# Altium schematic sheet peripheral device.SchDoc("peripheral device")
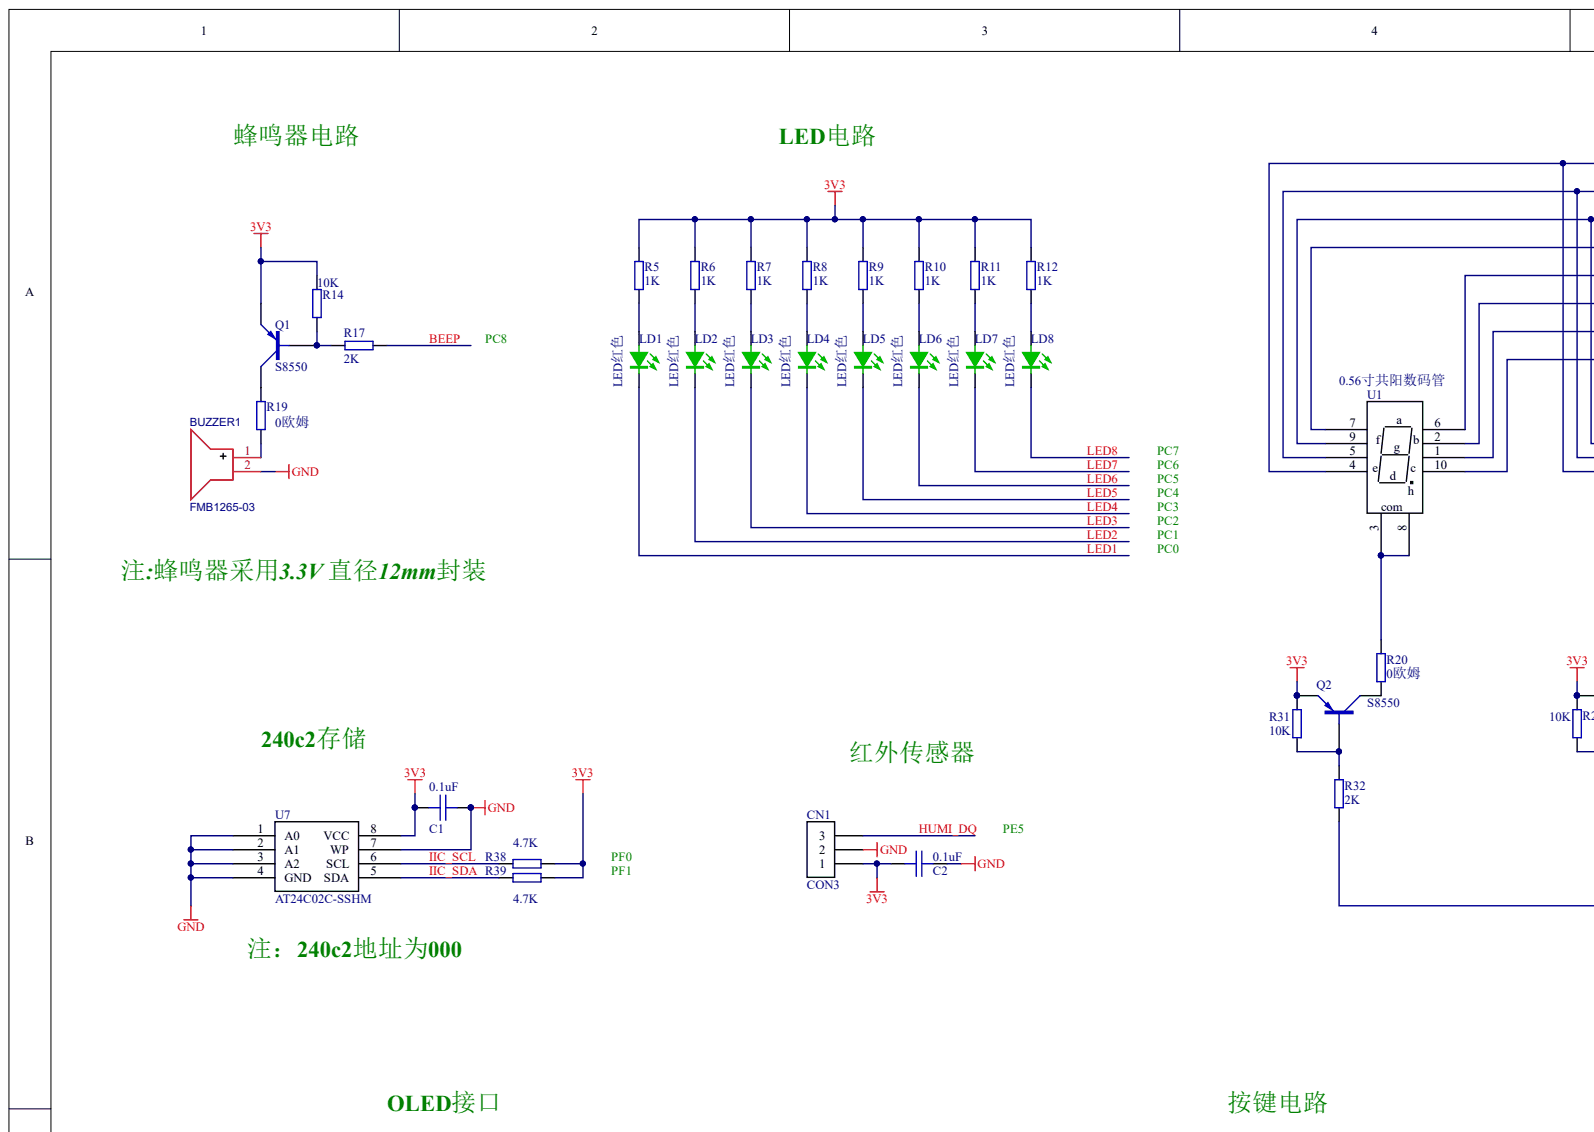
[diagram p0: peripheral device.SchDoc, top left]
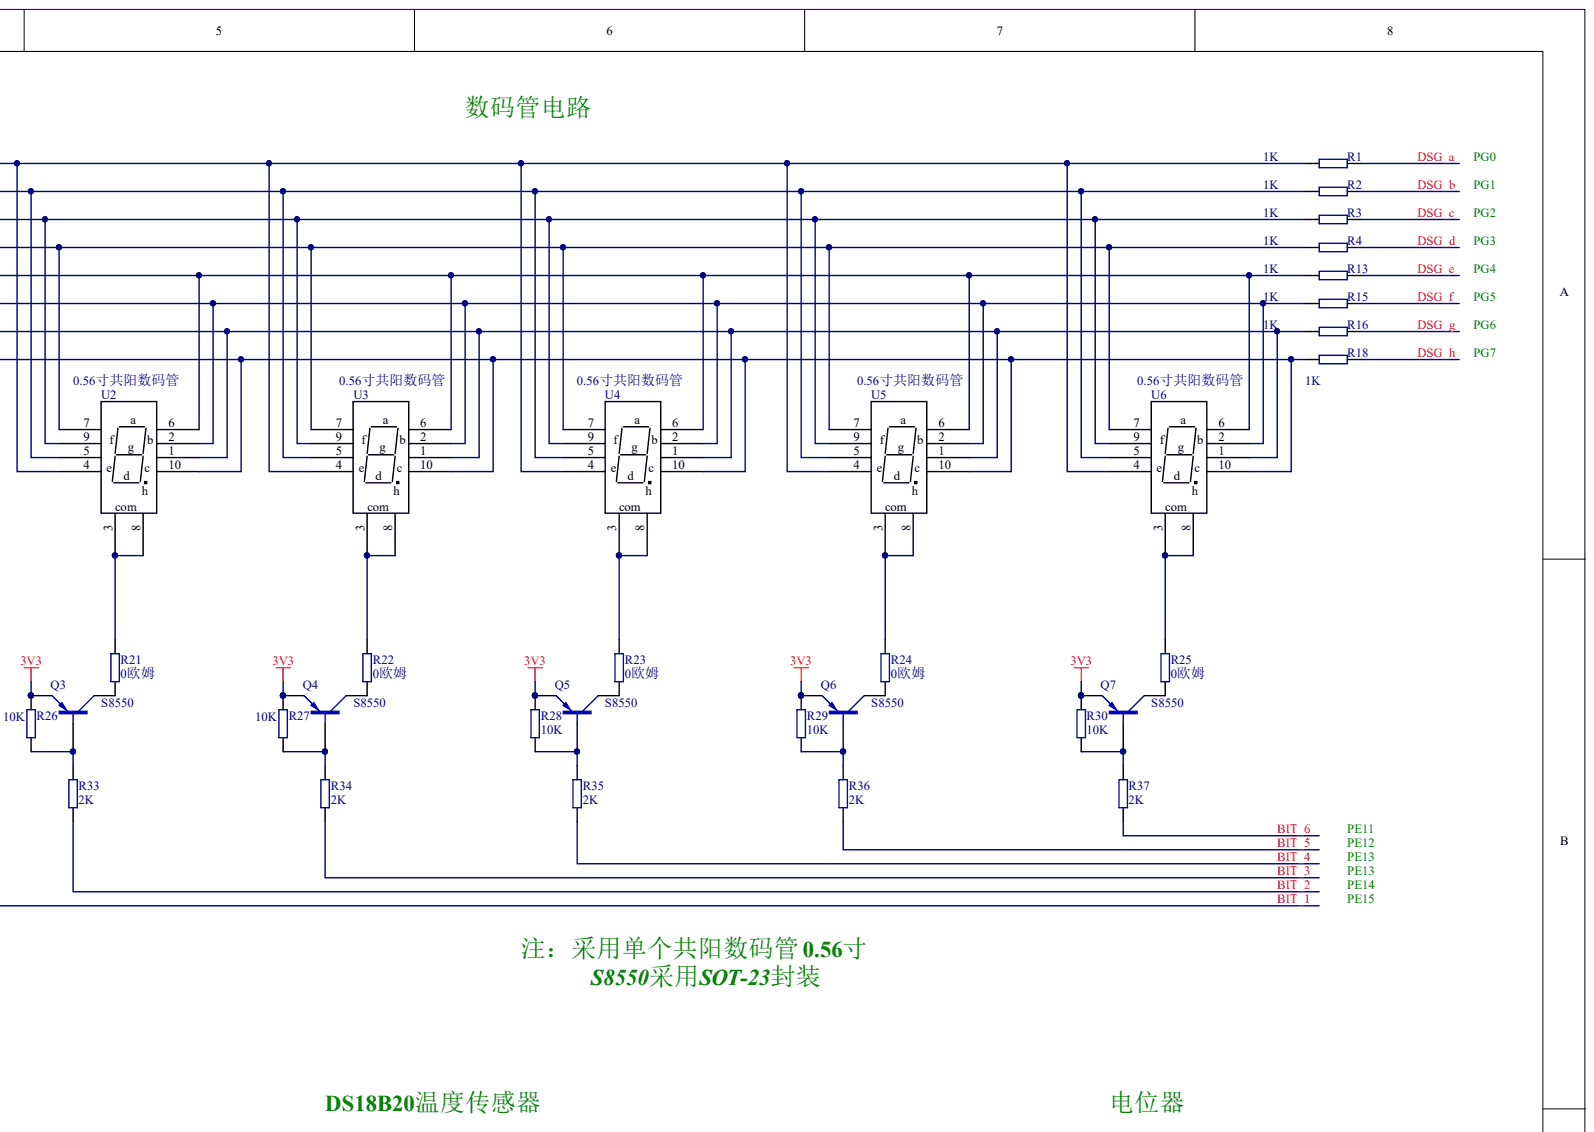
[diagram p1: peripheral device.SchDoc, top right]
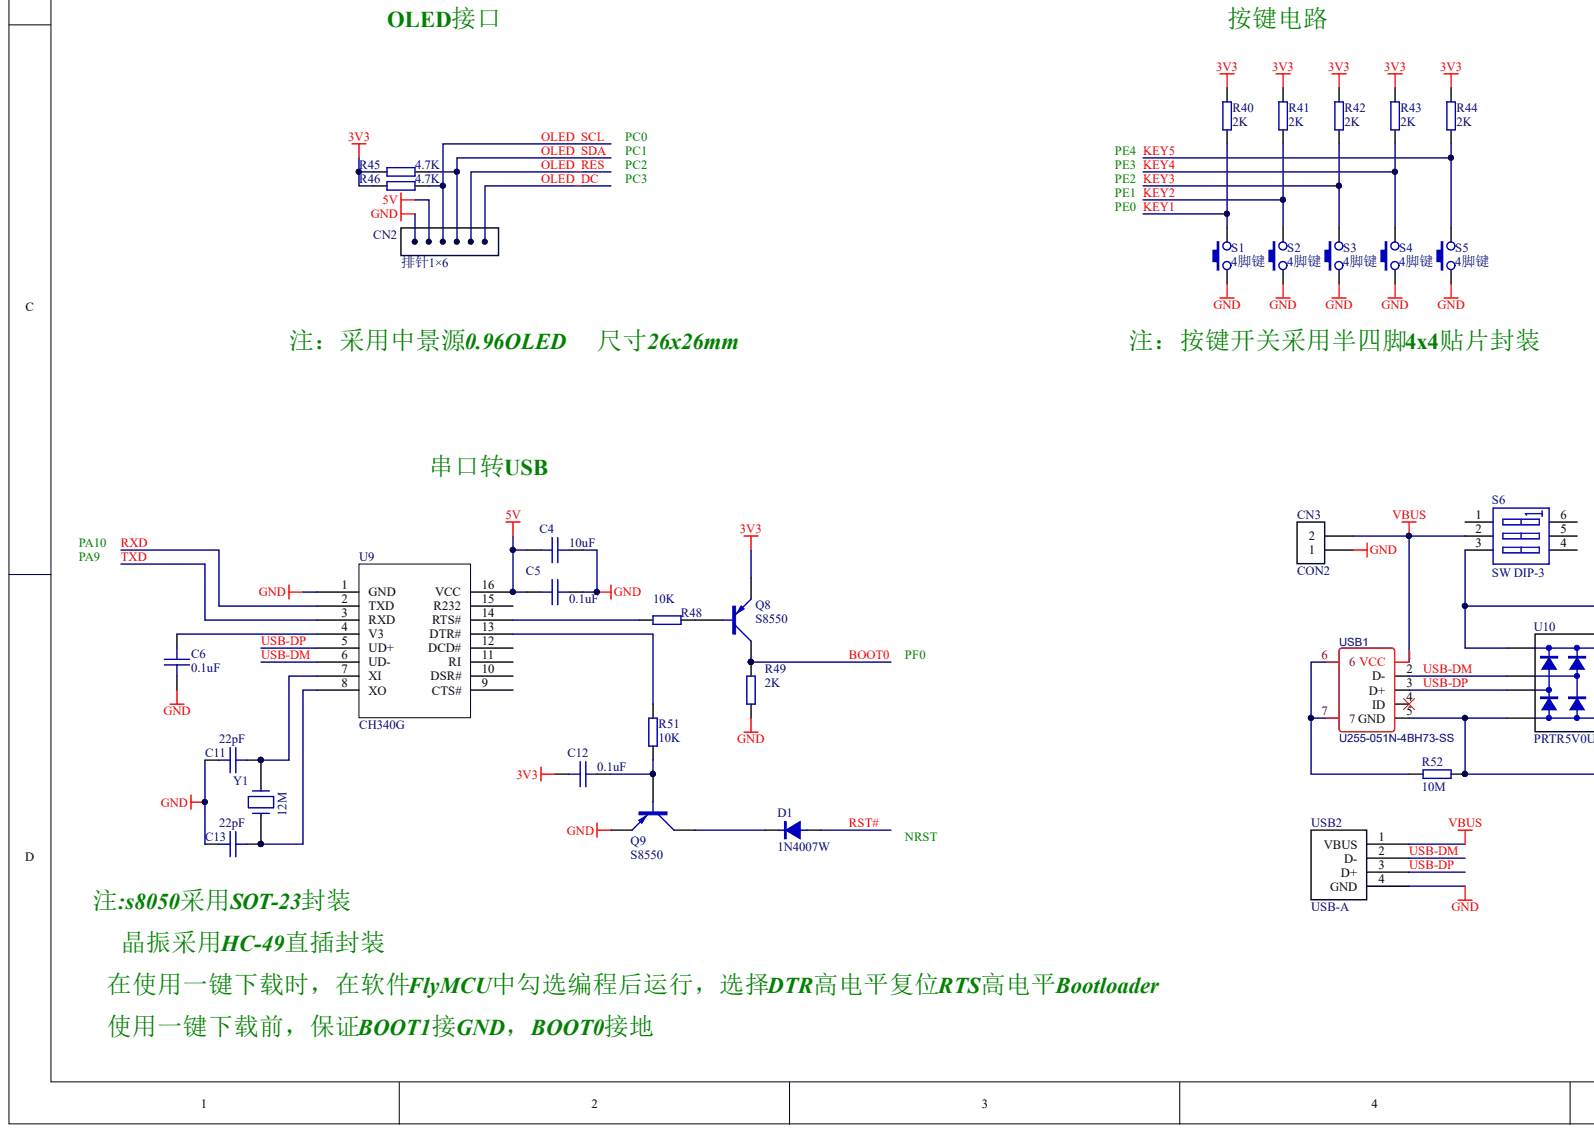
[diagram p2: peripheral device.SchDoc, bottom left]
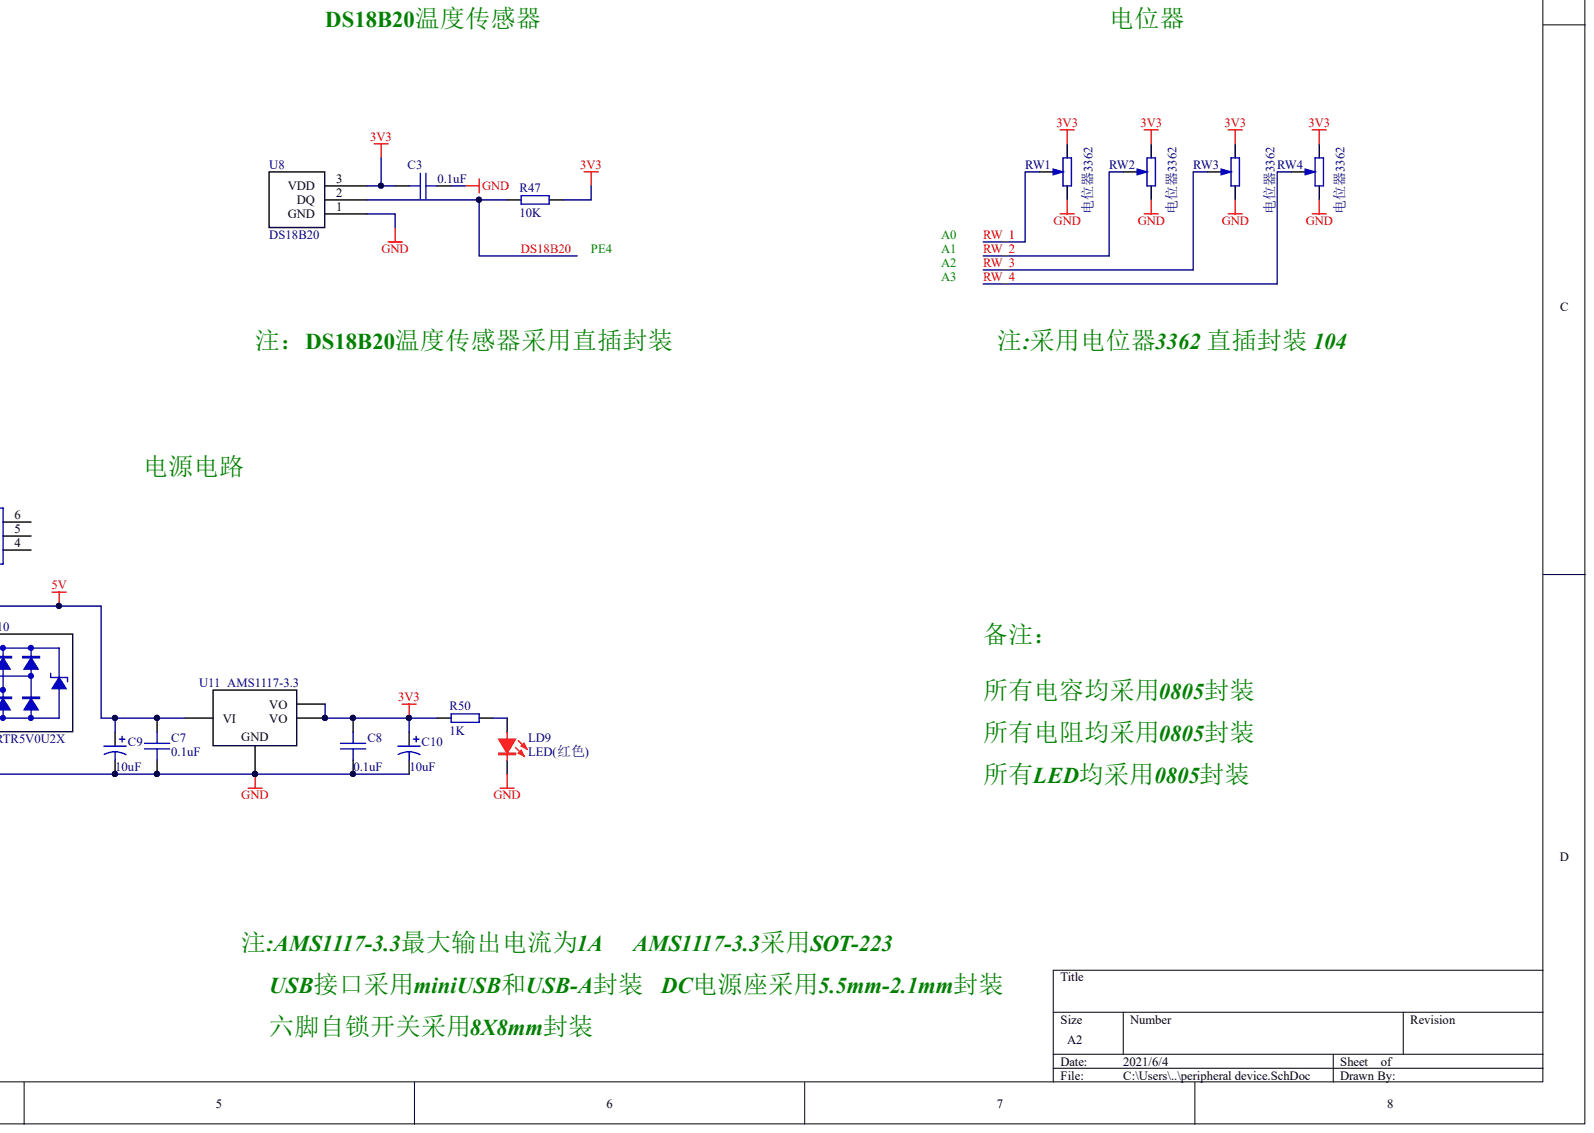
[diagram p3: peripheral device.SchDoc, bottom right]

## Parts
BUZZER1  FMB1265-03  [BUZ-TH_BD12.0-P7.60-D0.6-FD]
C1  0.1uF  [0805C]
C2  0.1uF  [0805C]
C3  0.1uF  [0805C]
C4  10uF  [0805C]
C5  0.1uF  [0805C]
C6  0.1uF  [0805C]
C7  0.1uF  [0805C]
C8  0.1uF  [0805C]
C9  10uF  [3528]
C10  10uF  [3528]
C11  22pF  [0805C]
C12  0.1uF  [0805C]
C13  22pF  [0805C]
CN1  CON3  [SIP-3(2.54)]
CN2  排针1×6  [OLED-0.96]
CN3  CON2  [CONN-TH_2P-P3.81_KF124-3.81-2P]
D1  1N4007W  [SOD-123]
LD1  LED红色  [0805D]
LD2  LED红色  [0805D]
LD3  LED红色  [0805D]
LD4  LED红色  [0805D]
LD5  LED红色  [0805D]
LD6  LED红色  [0805D]
LD7  LED红色  [0805D]
LD8  LED红色  [0805D]
LD9  LED(红色)  [0805D]
Q1  S8550  [SOT-23(2.4)]
Q2  S8550  [SOT-23(2.4)]
Q3  S8550  [SOT-23(2.4)]
Q4  S8550  [SOT-23(2.4)]
Q5  S8550  [SOT-23(2.4)]
Q6  S8550  [SOT-23(2.4)]
Q7  S8550  [SOT-23(2.4)]
Q8  S8550  [SOT-23(2.4)]
Q9  S8550  [SOT-23(2.4)]
R1  1K  [0805R]
R2  1K  [0805R]
R3  1K  [0805R]
R4  1K  [0805R]
R5  1K  [0805R]
R6  1K  [0805R]
R7  1K  [0805R]
R8  1K  [0805R]
R9  1K  [0805R]
R10  1K  [0805R]
R11  1K  [0805R]
R12  1K  [0805R]
R13  1K  [0805R]
R14  10K  [0805R]
R15  1K  [0805R]
R16  1K  [0805R]
R17  2K  [0805R]
R18  1K  [0805R]
R19  0欧姆  [0805R]
R20  0欧姆  [0805R]
R21  0欧姆  [0805R]
R22  0欧姆  [0805R]
R23  0欧姆  [0805R]
R24  0欧姆  [0805R]
R25  0欧姆  [0805R]
R26  10K  [0805R]
R27  10K  [0805R]
R28  10K  [0805R]
R29  10K  [0805R]
R30  10K  [0805R]
R31  10K  [0805R]
R32  2K  [0805R]
R33  2K  [0805R]
R34  2K  [0805R]
R35  2K  [0805R]
R36  2K  [0805R]
R37  2K  [0805R]
R38  4.7K  [0805R]
R39  4.7K  [0805R]
R40  2K  [0805R]
R41  2K  [0805R]
R42  2K  [0805R]
R43  2K  [0805R]
R44  2K  [0805R]
R45  4.7K  [0805R]
R46  4.7K  [0805R]
R47  10K  [0805R]
R48  10K  [0805R]
R49  2K  [0805R]
R50  1K  [0805R]
R51  10K  [0805R]
R52  10M  [0805R]
RW1  电位器3362  [电位器3362]
RW2  电位器3362  [电位器3362]
RW3  电位器3362  [电位器3362]
RW4  电位器3362  [电位器3362]
S1  4脚键  [KEY4x4]
S2  4脚键  [KEY4x4]
S3  4脚键  [KEY4x4]
S4  4脚键  [KEY4x4]
S5  4脚键  [KEY4x4]
S6  SW DIP-3  [六脚按键开关]
U1  0.56寸共阳数码管  [single]
U2  0.56寸共阳数码管  [single]
U3  0.56寸共阳数码管  [single]
U4  0.56寸共阳数码管  [single]
U5  0.56寸共阳数码管  [single]
U6  0.56寸共阳数码管  [single]
U7  AT24C02C-SSHM  [SOP-8(1.27,6.0)]
U8  DS18B20  [TO-92]
U9  CH340G  [SOP-16(1.27,6.0)]
U10  PRTR5V0U2X  [SOT-143]
U11  AMS1117-3.3  [SOT-223]
USB1  U255-051N-4BH73-SS  [MICRO-USB-TH_U255-051N-4BH73]
USB2  USB-A  [USB-A-TH_USB-302-T]
Y1  12M  [XTAL(HC-49)]

## Nets
3V3: C1.2 C2.1 C3.1 C8.2 C10.1 C12.1 CN1.1 Q1.2 Q2.2 Q3.2 Q4.2 Q5.2 Q6.2 Q7.2 Q8.2 R5.2 R6.2 R7.2 R8.2 R9.2 R10.2 R11.2 R12.2 R14.2 R26.2 R27.2 R28.2 R29.2 R30.2 R31.2 R38.2 R39.2 R40.2 R41.2 R42.2 R43.2 R44.2 R45.2 R46.2 R47.1 R50.1 RW1.1 RW2.1 RW3.1 RW4.1 U7.8(VCC) U8.3 U11.2(VO) U11.4(VO)
5V: C4.1 C5.1 C7.2 C9.1 CN2.2 S6.3 U9.16(VCC) U10.4 U11.3(VI)
GND: BUZZER1.2 C1.1 C2.2 C3.2 C4.2 C5.2 C6.1 C7.1 C8.1 C9.2 C10.2 C11.1 C13.1 CN1.2 CN2.1 CN3.1 LD9.2 Q9.2 R49.2 R52.2 RW1.3 RW2.3 RW3.3 RW4.3 S1.1 S2.1 S3.1 S4.1 S5.1 U7.1(A0) U7.2(A1) U7.3(A2) U7.4(GND) U7.7(WP) U8.1 U9.1(GND) U10.1 U11.1 USB1.5(GND) USB2.4(GND)
VBUS: CN3.2 S6.2 USB1.1(VCC) USB2.1(VBUS)
BEEP: R17.2
BIT_1: R32.2
BIT_2: R33.2
BIT_3: R34.2
BIT_4: R35.2
BIT_5: R36.2
BIT_6: R37.2
BOOT0: Q8.3 R49.1
DS18B20: R47.2 U8.2
DSG_A: R1.1
DSG_B: R2.1
DSG_C: R3.1
DSG_D: R4.1
DSG_E: R13.1
DSG_F: R15.1
DSG_G: R16.1
DSG_H: R18.1
HUMI_DQ: CN1.3
IIC_SCL: R38.1 U7.6(SCL)
IIC_SDA: R39.1 U7.5(SDA)
KEY1: R40.1 S1.2
KEY2: R41.1 S2.2
KEY3: R42.1 S3.2
KEY4: R43.1 S4.2
KEY5: R44.1 S5.2
LED1: LD1.2
LED2: LD2.2
LED3: LD3.2
LED4: LD4.2
LED5: LD5.2
LED6: LD6.2
LED7: LD7.2
LED8: LD8.2
OLED_DC: CN2.6
OLED_RES: CN2.5
OLED_SCL: CN2.3 R46.1
OLED_SDA: CN2.4 R45.1
RST#: D1.1
RW_1: RW1.2
RW_2: RW2.2
RW_3: RW3.2
RW_4: RW4.2
RXD: U9.2(TXD)
TXD: U9.3(RXD)
USB-DM: U9.6(UD-) U10.3 USB1.2(D-) USB2.2(D-)
USB-DP: U9.5(UD+) U10.2 USB1.3(D+) USB2.3(D+)
NetBUZZER1_1: BUZZER1.1 R19.2
NetC6_2: C6.2 U9.4(V3)
NetC11_2: C11.2 U9.7(XI) Y1.1
NetC12_2: C12.2 Q9.1 R51.2
NetC13_2: C13.2 U9.8(XO) Y1.2
NetD1_2: D1.2 Q9.3
NetLD1_1: LD1.1 R5.1
NetLD2_1: LD2.1 R6.1
NetLD3_1: LD3.1 R7.1
NetLD4_1: LD4.1 R8.1
NetLD5_1: LD5.1 R9.1
NetLD6_1: LD6.1 R10.1
NetLD7_1: LD7.1 R11.1
NetLD8_1: LD8.1 R12.1
NetLD9_1: LD9.1 R50.2
NetQ1_1: Q1.1 R14.1 R17.1
NetQ1_3: Q1.3 R19.1
NetQ2_1: Q2.1 R31.1 R32.1
NetQ2_3: Q2.3 R20.1
NetQ3_1: Q3.1 R26.1 R33.1
NetQ3_3: Q3.3 R21.1
NetQ4_1: Q4.1 R27.1 R34.1
NetQ4_3: Q4.3 R22.1
NetQ5_1: Q5.1 R28.1 R35.1
NetQ5_3: Q5.3 R23.1
NetQ6_1: Q6.1 R29.1 R36.1
NetQ6_3: Q6.3 R24.1
NetQ7_1: Q7.1 R30.1 R37.1
NetQ7_3: Q7.3 R25.1
NetQ8_1: Q8.1 R48.1
NetR1_2: R1.2 U1.4(e) U2.4(e) U3.4(e) U4.4(e) U5.4(e) U6.4(e)
NetR2_2: R2.2 U1.5 U2.5 U3.5 U4.5 U5.5 U6.5
NetR3_2: R3.2 U1.9(f) U2.9(f) U3.9(f) U4.9(f) U5.9(f) U6.9(f)
NetR4_2: R4.2 U1.7 U2.7 U3.7 U4.7 U5.7 U6.7
NetR13_2: R13.2 U1.6 U2.6 U3.6 U4.6 U5.6 U6.6
NetR15_2: R15.2 U1.2(b) U2.2(b) U3.2(b) U4.2(b) U5.2(b) U6.2(b)
NetR16_2: R16.2 U1.1 U2.1 U3.1 U4.1 U5.1 U6.1
NetR18_2: R18.2 U1.10(c) U2.10(c) U3.10(c) U4.10(c) U5.10(c) U6.10(c)
NetR20_2: R20.2 U1.3 U1.8(h)
NetR21_2: R21.2 U2.3 U2.8(h)
NetR22_2: R22.2 U3.3 U3.8(h)
NetR23_2: R23.2 U4.3 U4.8(h)
NetR24_2: R24.2 U5.3 U5.8(h)
NetR25_2: R25.2 U6.3 U6.8(h)
NetR48_2: R48.2 U9.14(RTS#)
NetR51_1: R51.1 U9.13(DTR#)
NetR52_1: R52.1 USB1.6 USB1.7

Not connected: S6 1 4 5 6; U9 9(CTS#) 10(DSR#) 11(RI) 12(DCD#) 15(R232); USB1 4(ID)

## Library data of the parts
BUZZER1 = FMB1265-03: BOM_Manufacturer: FUET; Contributor: LCSC; BOM_JLCPCB Part Class: Extended Part; BOM_Manufacturer Part: FMB1265-03; BOM_Supplier: LCSC; BOM_Supplier Part: C482354; Component Kind: Standard; Library Name: BUZZ-3v3.SCHLIB; spicePre: B; spiceSymbolName: FMB1265-03
C1-C8 C11-C13 = 0805电容: Component Kind: Standard; Library Name: 电容Capacitor.SchLib
C9 C10 = Cap Pol1: Polarized Capacitor (Radial); Component Kind: Standard; LatestRevisionDate: 17-Jul-2002; LatestRevisionNote: Re-released for DXP Platform.; Library Name: Miscellaneous Devices.IntLib; PackageDescription: Polarized Capacitor (Radial); 2 Leads; PackageReference: RB7.6-15; Published: 8-Jun-2000; Signal Integrity: Cap Pol; Simulation: CAP; Value: 10uF; Publisher: Altium Limited
D1 = 1N4007W: 1A整流二极管; Component Kind: Standard; Library Name: 二极管Diode.SchLib
LD1-LD8 = LED(翠绿): Component Kind: Standard; Library Name: 二极管Diode.SchLib
Q1-Q9 = S8550: PNP晶体管，500mA，25V; Component Kind: Standard; Library Name: 晶体管Transistor.SchLib
R1-R52 = 0805电阻: Component Kind: Standard; Library Name: 电阻Resistor.SchLib
RW1-RW4 = 电位器3296W: Component Kind: Standard; Library Name: 电阻Resistor.SchLib
S1-S5 = 2脚键: Component Kind: Standard; Library Name: 按键开关Switch.SchLib
S6 = SW DIP-3: DIP Switch, 3 Position, SPST; Code_JEDEC: MO-001-AM; Component Kind: Standard; LatestRevisionDate: 17-Jul-2002; LatestRevisionNote: Re-released for DXP Platform.; Library Name: Miscellaneous Devices.IntLib; PackageReference: DIP-6; Published: 24-Mar-1999; Simulation: dpsw3; Publisher: Altium Limited
U1-U6 = single: Component Kind: Standard; Library Name: 显示Display.SchLib
U7 = AT24C02C-SSHM: ATMEL，256×8bit EEPROM，I2C接口，1.7～5.5V; Component Kind: Standard; Library Name: 存储器Memory.SchLib
U8 = DS18B20: 单线数字温度传感器，范围－55～125℃，精度±0.5℃@－10～＋85℃; Component Kind: Standard; Library Name: 传感器Sensor.SchLib
U10 = PRTR5V0U2X: NXP恩智浦，双路ESD保护二极管; Component Kind: Standard; Library Name: 电路保护Protection.SchLib
U11 = AMS1117-3.3: AMS，800mA LDO，输出3.3V，输入最高18V; Component Kind: Standard; Library Name: 电源Power.SchLib
USB1 = U255-051N-4BH73-SS: BOM_Manufacturer: XKB Enterprise; Contributor: LCSC; BOM_JLCPCB Part Class: Extended Part; BOM_Manufacturer Part: U255-051N-4BH73-SS; BOM_Supplier: LCSC; BOM_Supplier Part: C571309; Component Kind: Standard; Library Name: USB-DIP.SCHLIB; spicePre: U; spiceSymbolName: U255-051N-4BH73-SS
USB2 = USB-A: Text Field1: 50; Component Kind: Standard; Text Field2: 50; Library Name: 通信调试接口.SchLib
Y1 = XTAL(HC-49): Component Kind: Standard; Library Name: 时钟振荡日历OSC-RTC.SchLib
CN1 = CON3: Component Kind: Standard; Library Name: 连接器Connector.SchLib
CN2 = 排针1×6: Component Kind: Standard; Library Name: 连接器Connector.SchLib
CN3 = CON2: Component Kind: Standard; Library Name: 连接器Connector.SchLib
LD9 = LED(红色): Component Kind: Standard; Library Name: 二极管Diode.SchLib
U9 = CH340G: Component Kind: Standard; Library Name: 接口与隔离Interface.SchLib
